# Altium schematic sheet 12_ATK-DLMP257_WIFI_TF_OTG.SchDoc
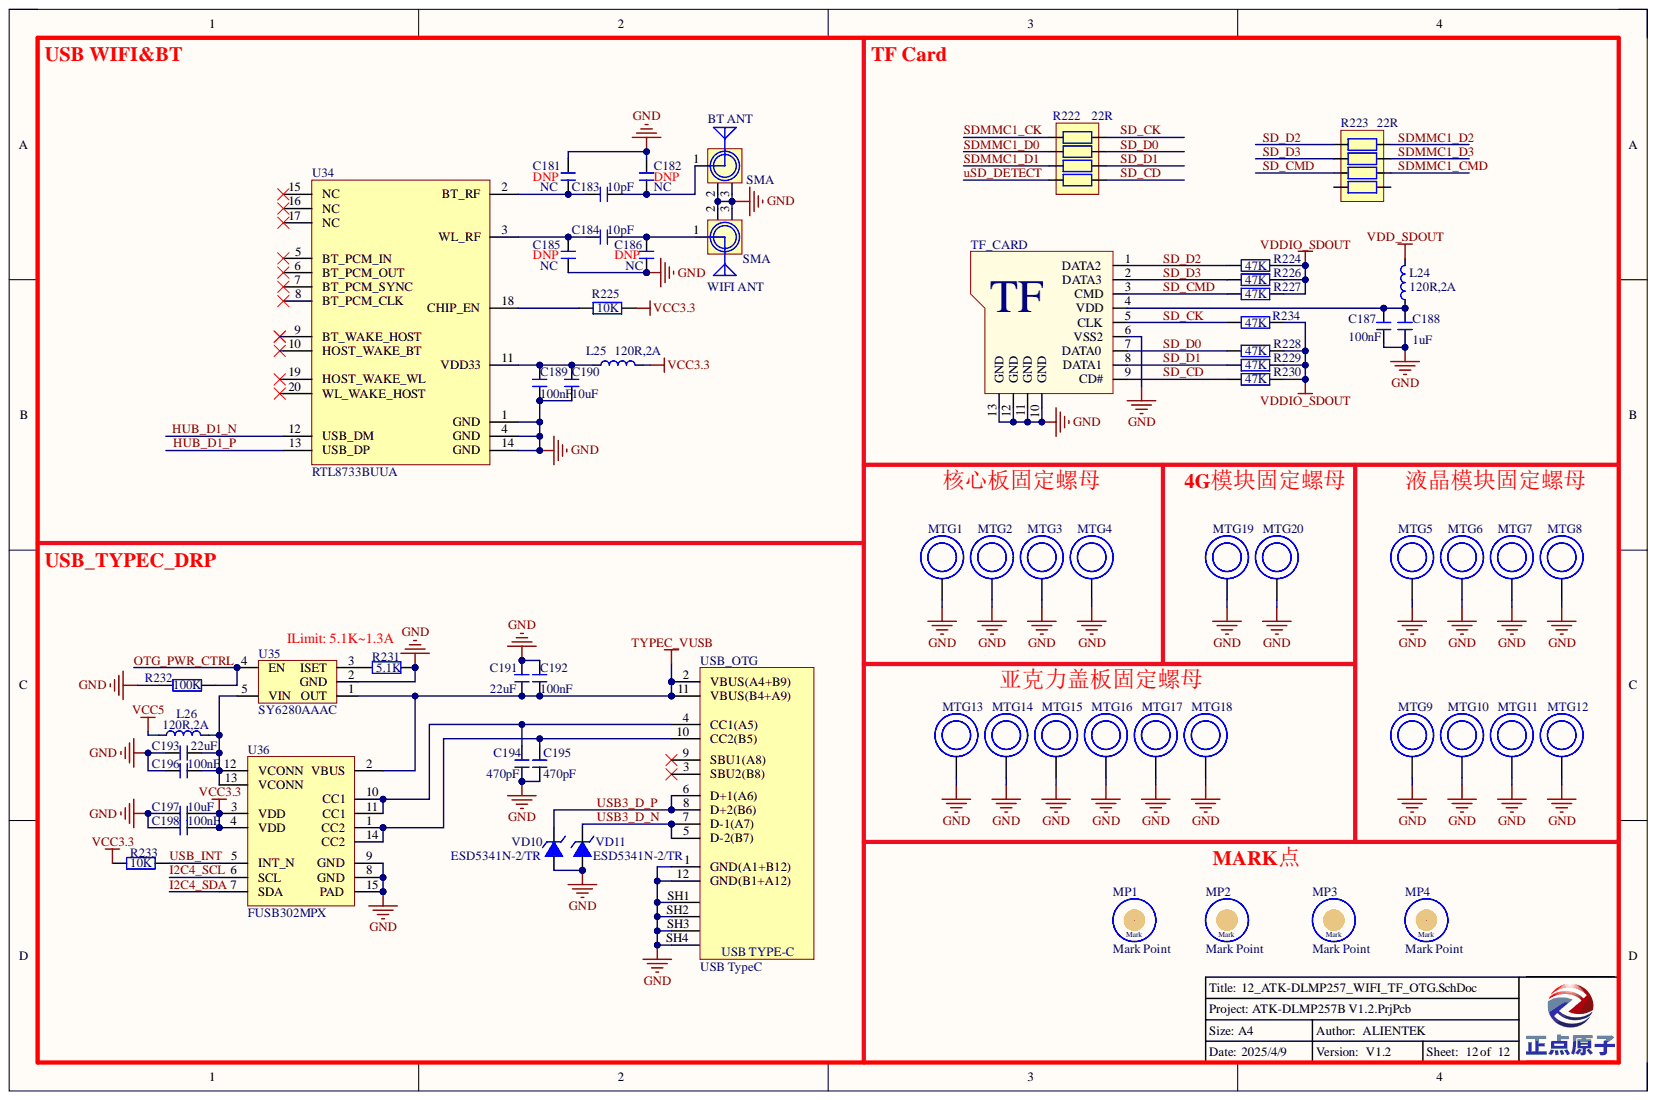
[diagram p0: 12_ATK-DLMP257_WIFI_TF_OTG.SchDoc]

## Parts
BT_ANT  SMA  [SMA-KWE]
C181  NC  [C 0402]
C182  NC  [C 0402]
C183  10pF  [C 0402]
C184  10pF  [C 0402]
C185  NC  [C 0402]
C186  NC  [C 0402]
C187  100nF  [C 0603]
C188  1uF  [C 0603]
C189  100nF  [C 0603]
C190  10uF  [C 0805]
C191  22uF  [C 0805]
C192  100nF  [C 0603]
C193  22uF  [C 0805]
C194  470pF  [C 0603]
C195  470pF  [C 0603]
C196  100nF  [C 0603]
C197  10uF  [C 0603]
C198  100nF  [C 0603]
L24  120R,2A  [L 0603]
L25  120R,2A  [L 0603]
L26  120R,2A  [L 0603]
MP1  Mark Point  [MARK POINT]
MP2  Mark Point  [MARK POINT]
MP3  Mark Point  [MARK POINT]
MP4  Mark Point  [MARK POINT]
MTG1  螺母(M2,贴片螺母柱,铜镀镍,M2x4x1.5+2.9x1.0)  [M2螺丝贴片螺母-直径4mm-高1.5mm]  (value not drawn)
MTG2  螺母(M2,贴片螺母柱,铜镀镍,M2x4x1.5+2.9x1.0)  [M2螺丝贴片螺母-直径4mm-高1.5mm]  (value not drawn)
MTG3  螺母(M2,贴片螺母柱,铜镀镍,M2x4x1.5+2.9x1.0)  [M2螺丝贴片螺母-直径4mm-高1.5mm]  (value not drawn)
MTG4  螺母(M2,贴片螺母柱,铜镀镍,M2x4x1.5+2.9x1.0)  [M2螺丝贴片螺母-直径4mm-高1.5mm]  (value not drawn)
MTG5  螺母(M3*6,贴片螺母柱,白色,SMTSO-M3-6ET)  [M3*23+6]  (value not drawn)
MTG6  螺母(M3*6,贴片螺母柱,白色,SMTSO-M3-6ET)  [M3*23+6]  (value not drawn)
MTG7  螺母(M3*6,贴片螺母柱,白色,SMTSO-M3-6ET)  [M3*23+6]  (value not drawn)
MTG8  螺母(M3*6,贴片螺母柱,白色,SMTSO-M3-6ET)  [M3*23+6]  (value not drawn)
MTG9  螺母(M3*6,贴片螺母柱,白色,SMTSO-M3-6ET)  [M3*23+6]  (value not drawn)
MTG10  螺母(M3*6,贴片螺母柱,白色,SMTSO-M3-6ET)  [M3*23+6]  (value not drawn)
MTG11  螺母(M3*6,贴片螺母柱,白色,SMTSO-M3-6ET)  [M3*23+6]  (value not drawn)
MTG12  螺母(M3*6,贴片螺母柱,白色,SMTSO-M3-6ET)  [M3*23+6]  (value not drawn)
MTG13  螺母(M3*6,贴片螺母柱,白色,SMTSO-M3-6ET)  [M3-6ET]  (value not drawn)
MTG14  螺母(M3*6,贴片螺母柱,白色,SMTSO-M3-6ET)  [M3-6ET]  (value not drawn)
MTG15  螺母(M3*6,贴片螺母柱,白色,SMTSO-M3-6ET)  [M3-6ET]  (value not drawn)
MTG16  螺母(M3*6,贴片螺母柱,白色,SMTSO-M3-6ET)  [M3-6ET]  (value not drawn)
MTG17  螺母(M3*6,贴片螺母柱,白色,SMTSO-M3-6ET)  [M3-6ET]  (value not drawn)
MTG18  螺母(M3*6,贴片螺母柱,白色,SMTSO-M3-6ET)  [M3-6ET]  (value not drawn)
MTG19  螺母(M3*6,贴片螺母柱,白色,SMTSO-M3-6ET)  [铜柱(M2.5,贴片铜柱,黄铜,2.9*2.2+4.0*4.3mm)]  (value not drawn)
MTG20  螺母(M3*6,贴片螺母柱,白色,SMTSO-M3-6ET)  [铜柱(M2.5,贴片铜柱,黄铜,2.9*2.2+4.0*4.3mm)]  (value not drawn)
R222  22R  [RCA-8P4R-0603]
R223  22R  [RCA-8P4R-0603]
R224  47K  [R 0603]
R225  10K  [R 0603]
R226  47K  [R 0603]
R227  47K  [R 0603]
R228  47K  [R 0603]
R229  47K  [R 0603]
R230  47K  [R 0603]
R231  5.1K  [R 0603]
R232  100K  [R 0603]
R233  10K  [R 0603]
R234  47K  [R 0603]
TF_CARD  SD-MICRO-TF  [SD-MICRO-TF]  (value not drawn)
U34  RTL8733BUUA  [RTL8733BUBUA]
U35  SY6280AAAC  [SOT23-5N]
U36  FUSB302MPX  [MLP14]
USB_OTG  USB TypeC  [USB2.0-TYPE-C-16P]
VD10  ESD5341N-2/TR  [ESD0402]
VD11  ESD5341N-2/TR  [ESD0402]
WIFI_ANT  SMA  [SMA-KWE]

## Nets
GND: BT_ANT.2 BT_ANT.3 C181.1 C182.1 C185.2 C186.2 C187.2 C188.1 C189.2 C190.2 C191.1 C192.1 C193.1 C194.2 C195.1 C196.1 C197.1 C198.1 MTG1.1 MTG2.1 MTG3.1 MTG4.1 MTG5.1 MTG6.1 MTG7.1 MTG8.1 MTG9.1 MTG10.1 MTG11.1 MTG12.1 MTG13.1 MTG14.1 MTG15.1 MTG16.1 MTG17.1 MTG18.1 MTG19.0 MTG20.0 R231.2 R232.1 TF_CARD.6(VSS2) TF_CARD.10(GND) TF_CARD.11(GND) TF_CARD.12(GND) TF_CARD.13(GND) U34.1(GND) U34.4(GND) U34.14(GND) U35.2(GND) U36.8(GND) U36.9(GND) U36.15(PAD) USB_OTG.1(GND(A1+B12)) USB_OTG.12(GND(B1+A12)) USB_OTG.SH1(Shield1) USB_OTG.SH2(Shield2) USB_OTG.SH3(Shield3) USB_OTG.SH4(Shield4) VD10.2 VD11.2 WIFI_ANT.2 WIFI_ANT.3
TYPEC_VUSB: C191.2 C192.2 U35.1(OUT) U36.2(VBUS) USB_OTG.2(VBUS(A4+B9)) USB_OTG.11(VBUS(B4+A9))
VCC3.3: C197.2 C198.2 L25.2 R225.1 R233.2 U36.3(VDD) U36.4(VDD)
VCC5: L26.1
VDD_SDOUT: L24.2
VDDIO_SDOUT: R224.1 R226.1 R227.1 R228.1 R229.1 R230.1 R234.1
HUB_D1_N: U34.12(USB_DM)
HUB_D1_P: U34.13(USB_DP)
I2C4_SCL: U36.6(SCL)
I2C4_SDA: U36.7(SDA)
OTG_PWR_CTRL: R232.2 U35.4(EN)
SD_CD: R222.1 R230.2 TF_CARD.9(CD#)
SD_CK: R222.4 R234.2 TF_CARD.5(CLK)
SD_CMD: R223.2 R227.2 TF_CARD.3(CMD)
SD_D0: R222.3 R228.2 TF_CARD.7(DATA0)
SD_D1: R222.2 R229.2 TF_CARD.8(DATA1)
SD_D2: R223.4 R224.2 TF_CARD.1(DATA2)
SD_D3: R223.3 R226.2 TF_CARD.2(DATA3)
SDMMC1_CK: R222.5
SDMMC1_CMD: R223.7
SDMMC1_D0: R222.6
SDMMC1_D1: R222.7
SDMMC1_D2: R223.5
SDMMC1_D3: R223.6
USB3_D_N: USB_OTG.5(D-2(B7)) USB_OTG.7(D-1(A7)) VD11.1
USB3_D_P: USB_OTG.6(D+1(A6)) USB_OTG.8(D+2(B6)) VD10.1
USB_INT: R233.1 U36.5(INT_N)
uSD_DETECT: R222.8
NetBT ANT_1: BT_ANT.1 C182.2 C183.1
NetC181_2: C181.2 C183.2 U34.2(BT_RF)
NetC184_1: C184.1 C186.1 WIFI_ANT.1
NetC184_2: C184.2 C185.1 U34.3(WL_RF)
NetC187_1: C187.1 C188.2 L24.1 TF_CARD.4(VDD)
NetC189_1: C189.1 C190.1 L25.1 U34.11(VDD33)
NetC193_2: C193.2 C196.2 L26.2 U35.5(VIN) U36.12(VCONN) U36.13(VCONN)
NetC194_1: C194.1 U36.10(CC1) U36.11(CC1) USB_OTG.4(CC1(A5))
NetC195_2: C195.2 U36.1(CC2) U36.14(CC2) USB_OTG.10(CC2(B5))
NetR225_2: R225.2 U34.18(CHIP_EN)
NetR231_1: R231.1 U35.3(ISET)

Not connected: MP1 1; MP2 1; MP3 1; MP4 1; R223 1 8; U34 5(BT_PCM_IN) 6(BT_PCM_OUT) 7(BT_PCM_SYNC) 8(BT_PCM_CLK) 9(BT_WAKE_HOST) 10(HOST_WAKE_BT) 15(NC) 16(NC) 17(NC) 19(HOST_WAKE_WL) 20(WL_WAKE_HOST); USB_OTG 3(SBU2(B8)) 9(SBU1(A8))

## Library data of the parts
BT_ANT WIFI_ANT = SMA: SMA-2.4G射频天线接口; 版权归属: 正点原子; BOM名称: SMA-2.4G射频天线接口; Component Kind: Standard; Library Name: 接口类.SchLib; 学习资源: www.openedv.com
C181-C186 C189 C190 = C: 无极性贴片电容; 版权归属: 源创客; BOM名称: 无极性贴片电容; Component Kind: Standard; Library Name: 电阻-电容-电感.SchLib; 学习资源: www.ooocke.com
C187 C188 C191-C198 = C: 无极性贴片电容; 版权归属: 正点原子; BOM名称: 无极性贴片电容; Component Kind: Standard; 学习资源: www.openedv.com
  C193 C196: Library Name: 电阻-电容-电感.SchLib
  C187 C188 C191 C192 C194 C195 C197 C198: Library Name: Lycreturn.IntLib
L24 L26 = L: 小功率贴片电感磁珠; 版权归属: 正点原子; BOM名称: 小功率贴片电感; Component Kind: Standard; 学习资源: www.openedv.com
  L26: Library Name: 电阻-电容-电感.SchLib
  L24: Library Name: Lycreturn.IntLib
L25 = FB: 贴片磁珠; BOM名称: 小功率贴片电感; Parameter: 电感/磁珠; 版权归属: 正点原子; Component Kind: Standard; Library Name: 正点原子封装库V1.0.IntLib; 学习资源: www.openedv.com
MP1-MP4 = MARK: Component Kind: Standard; Description: Mark Point; Library Name: ATK_DLRK3588_V1.0_1.SCHLIB
MTG1-MTG4 = MTG_Hole: 301020006; Component Kind: Standard; Library Name: PGL22G_V1.0.SCHLIB
MTG5-MTG20 = MTG_Hole: 301020001; Component Kind: Standard; Library Name: PGL22G_V1.0.SCHLIB
R222 R223 = R-8P4R: 贴片网络排阻; 版权归属: 正点原子; BOM名称: 贴片网络排阻; Component Kind: Standard; Library Name: 电阻-电容-电感.SchLib; 学习资源: www.openedv.com
R224-R231 R233 R234 = R: 贴片电阻; 版权归属: 正点原子; BOM名称: 贴片电阻; Component Kind: Standard; 学习资源: www.openedv.com; Library Name: Lycreturn.IntLib
R232 = R: 电阻; BOM名称: 贴片电阻; 版权归属: 正点原子; Component Kind: Standard; Library Name: 正点原子封装库V1.0.IntLib; Parameter: 电阻; 学习资源: www.openedv.com
TF_CARD = SD-MICRO-TF: SD,MICRO,TF; Component Kind: Standard; Library Name: 正点原子封装库V1.0.IntLib
U35 = MT9700HT5: 功率开关(5.5V-2A); BOM名称: 稳压芯片; 版权归属: 正点原子; Component Kind: Standard; Library Name: LDO和DCDC.SchLib; 学习资源: www.openedv.com
U36 = FUSB302MPX: USB Type-C 控制器; Component Kind: Standard; Library Name: IC芯片.SchLib
USB_OTG = USB Type-C: 12P TypeC 母座; Component Kind: Standard; Library Name: 接口类.SchLib
VD10 VD11 = ESD5341N: ESD二极管-单向; Component Kind: Standard; Library Name: ATK-DLMP257_V1.0.IntLib
U34 = RTL8733BUUA: Component Kind: Standard; Library Name: ZZK_COMPONENT.IntLib
